# Altium schematic sheet SH1 - HSD.SchDoc
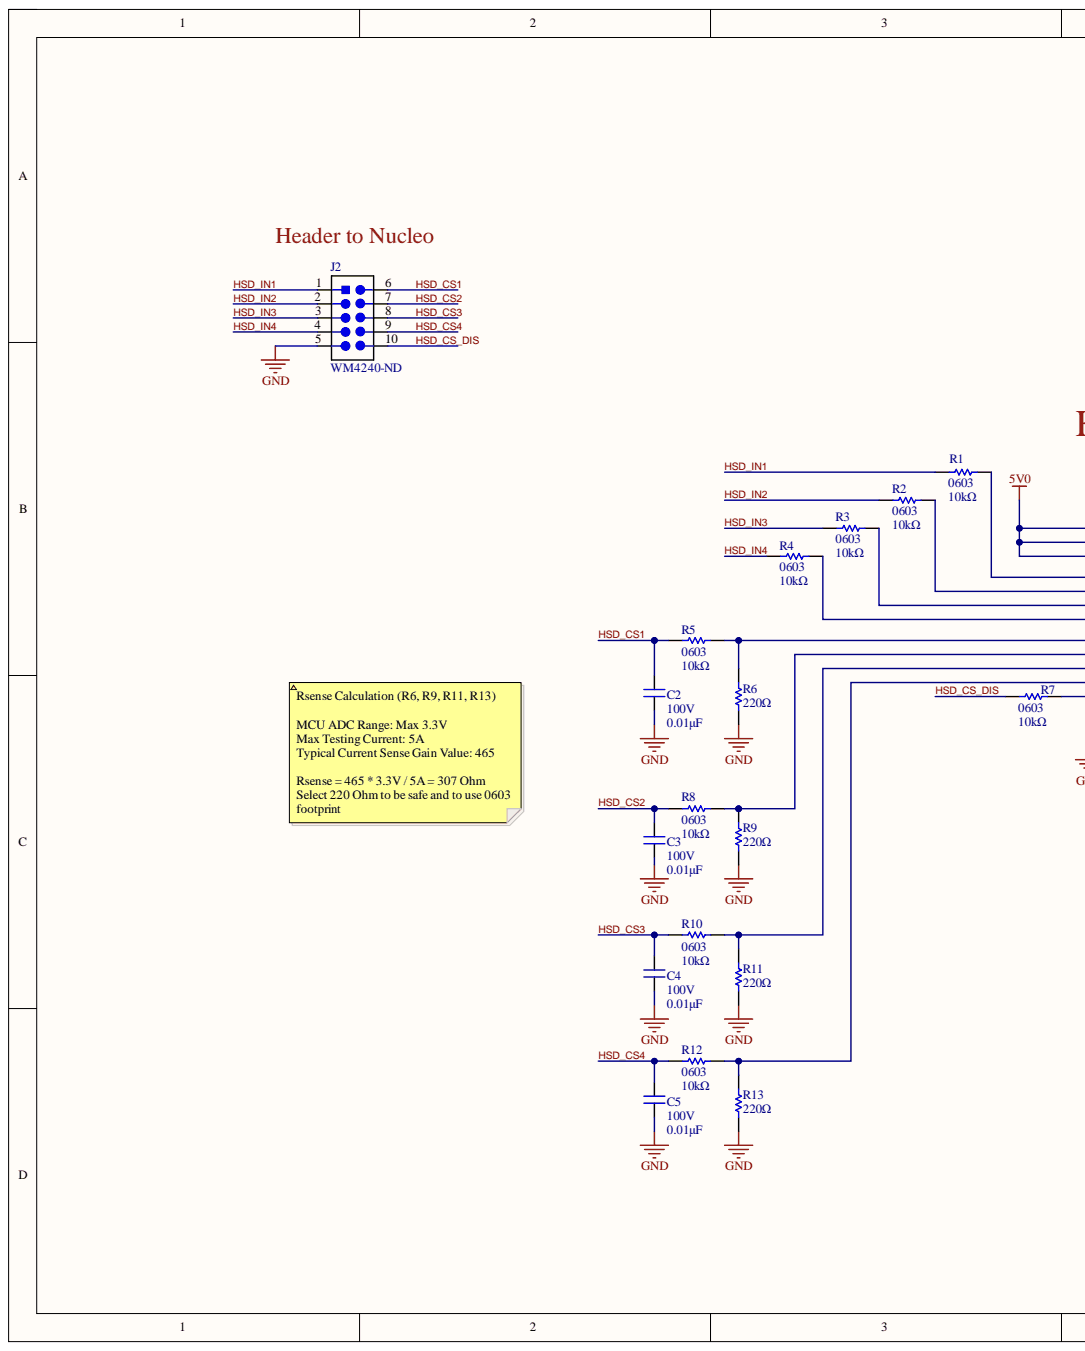
[diagram p0: SH1 - HSD.SchDoc, left]
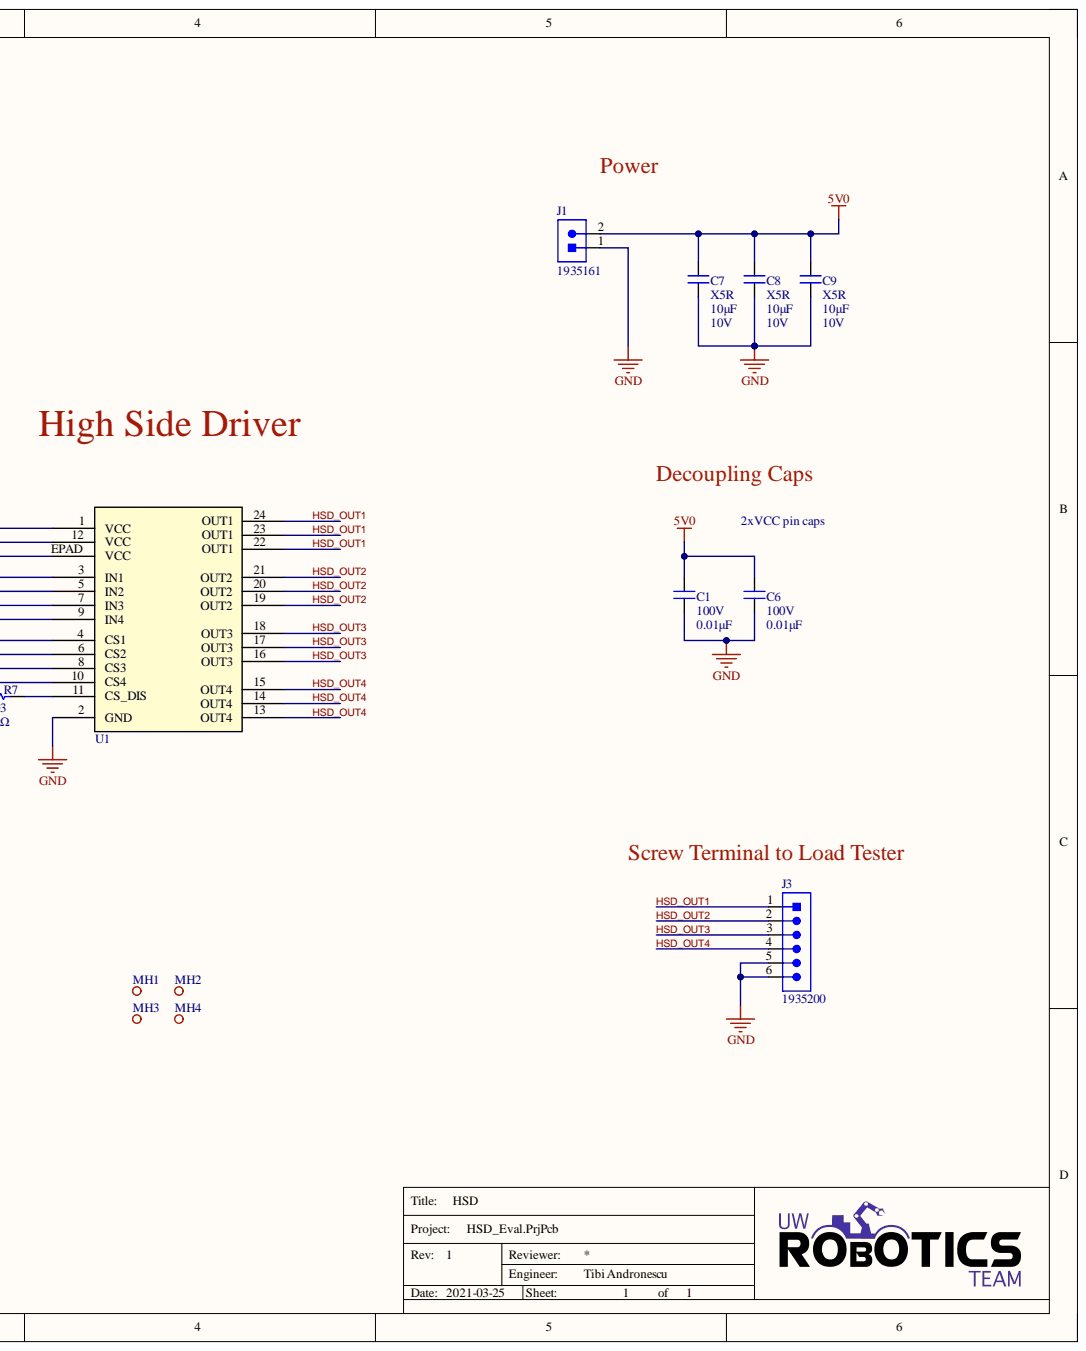
[diagram p1: SH1 - HSD.SchDoc, right]

## Parts
C1  value 0.01μF  Voltage Rating: 100V  [CAP0603]  (hidden comment: CAP_0.01uF_100V_0603)
C2  value 0.01μF  Voltage Rating: 100V  [CAP0603]  (hidden comment: CAP_0.01uF_100V_0603)
C3  value 0.01μF  Voltage Rating: 100V  [CAP0603]  (hidden comment: CAP_0.01uF_100V_0603)
C4  value 0.01μF  Voltage Rating: 100V  [CAP0603]  (hidden comment: CAP_0.01uF_100V_0603)
C5  value 0.01μF  Voltage Rating: 100V  [CAP0603]  (hidden comment: CAP_0.01uF_100V_0603)
C6  value 0.01μF  Voltage Rating: 100V  [CAP0603]  (hidden comment: CAP_0.01uF_100V_0603)
C7  value 10μF  Dielectric: X5R  Voltage Rating: 10V  [CAP0603]  (hidden comment: CAP_10uF_10V_0603)
C8  value 10μF  Dielectric: X5R  Voltage Rating: 10V  [CAP0603]  (hidden comment: CAP_10uF_10V_0603)
C9  value 10μF  Dielectric: X5R  Voltage Rating: 10V  [CAP0603]  (hidden comment: CAP_10uF_10V_0603)
J1  CON_2M_TERM  [1935161]  (value not drawn)
J2  Supplier Part Number 1: WM4240-ND  [HEADER 2X5]  (hidden comment: HEADER 5x2)
J3  CON_6POS_SCREW_TERM  [1935200]  (value not drawn)
MH1  MOUNTING_HOLE_M3  [MOUNTING_HOLE_3mm]  (value not drawn)  (no BOM)
MH2  MOUNTING_HOLE_M3  [MOUNTING_HOLE_3mm]  (value not drawn)  (no BOM)
MH3  MOUNTING_HOLE_M3  [MOUNTING_HOLE_3mm]  (value not drawn)  (no BOM)
MH4  MOUNTING_HOLE_M3  [MOUNTING_HOLE_3mm]  (value not drawn)  (no BOM)
R1  Package/Footprint: 0603  [RESC0603]  (hidden comment: RES_10kΩ_0603_5%)
R2  value 10kΩ  Package/Footprint: 0603  [RESC0603]  (hidden comment: RES_10kΩ_0603_5%)
R3  value 10kΩ  Package/Footprint: 0603  [RESC0603]  (hidden comment: RES_10kΩ_0603_5%)
R4  value 10kΩ  Package/Footprint: 0603  [RESC0603]  (hidden comment: RES_10kΩ_0603_5%)
R5  value 10kΩ  Package/Footprint: 0603  [RESC0603]  (hidden comment: RES_10kΩ_0603_5%)
R6  value 220Ω  [RESC0603]  (hidden comment: RES_220Ω_0603)
R7  value 10kΩ  Package/Footprint: 0603  [RESC0603]  (hidden comment: RES_10kΩ_0603_5%)
R8  value 10kΩ  Package/Footprint: 0603  [RESC0603]  (hidden comment: RES_10kΩ_0603_5%)
R9  value 220Ω  [RESC0603]  (hidden comment: RES_220Ω_0603)
R10  value 10kΩ  Package/Footprint: 0603  [RESC0603]  (hidden comment: RES_10kΩ_0603_5%)
R11  value 220Ω  [RESC0603]  (hidden comment: RES_220Ω_0603)
R12  value 10kΩ  Package/Footprint: 0603  [RESC0603]  (hidden comment: RES_10kΩ_0603_5%)
R13  value 220Ω  [RESC0603]  (hidden comment: RES_220Ω_0603)
U1  VNQ5E160AK-E  [PowerSSO-24_STM]  (value not drawn)

## Nets
5V0: C1.2 C6.2 C7.2 C8.2 C9.2 J1.2 U1.1(VCC) U1.12(VCC) U1.EPAD(VCC)
GND: C1.1 C2.1 C3.1 C4.1 C5.1 C6.1 C7.1 C8.1 C9.1 J1.1 J2.5 J3.5 J3.6 R6.1 R9.1 R11.1 R13.1 U1.2(GND)
HSD_CS1: C2.2 J2.6 R5.1
HSD_CS2: C3.2 J2.7 R8.1
HSD_CS3: C4.2 J2.8 R10.1
HSD_CS4: C5.2 J2.9 R12.1
HSD_CS_DIS: J2.10 R7.1
HSD_IN1: J2.1 R1.1
HSD_IN2: J2.2 R2.1
HSD_IN3: J2.3 R3.1
HSD_IN4: J2.4 R4.1
HSD_OUT1: J3.1 U1.22(OUT1) U1.23(OUT1) U1.24(OUT1)
HSD_OUT2: J3.2 U1.19(OUT2) U1.20(OUT2) U1.21(OUT2)
HSD_OUT3: J3.3 U1.16(OUT3) U1.17(OUT3) U1.18(OUT3)
HSD_OUT4: J3.4 U1.13(OUT4) U1.14(OUT4) U1.15(OUT4)
NetR1_2: R1.2 U1.3(IN1)
NetR2_2: R2.2 U1.5(IN2)
NetR3_2: R3.2 U1.7(IN3)
NetR4_2: R4.2 U1.9(IN4)
NetR5_2: R5.2 R6.2 U1.4(CS1)
NetR7_2: R7.2 U1.11(CS_DIS)
NetR8_2: R8.2 R9.2 U1.6(CS2)
NetR10_2: R10.2 R11.2 U1.8(CS3)
NetR12_2: R12.2 R13.2 U1.10(CS4)

## Library data of the parts
C1-C6 = CAP_0.01uF_100V_0603: CAP CER 10000PF 100V X7R 0603; Manufacturer 1: Murata Electronics; Manufacturer Part Number 1: GCM188R72A103KA37J; Component Kind: Standard; Dielectric: X7R; Supplier 1: Digi-Key; Supplier Part Number 1: 490-8028-1-ND; Library Name: UWRT_Schematic_Library_2020.SchLib
C7-C9 = CAP_10uF_10V_0603: CAP CER 10UF 10V X5R 0603; Manufacturer 1: Yageo; Manufacturer Part Number 1: CC0603MRX5R6BB106; Component Kind: Standard; Supplier 1: Digi-Key; Supplier Part Number 1: 311-1817-1-ND; Library Name: UWRT_Schematic_Library_2020.SchLib
J1 = CON_2M_TERM: TERM BLK 2POS SIDE ENTRY 5MM; Manufacturer 1: Phoenix Contact; Current: 18A; Max Current Rating: 16A; Package/Footprint: SMD; Voltage: 300V; Component Kind: Standard; Manufacturer Part Number 1: 1935161; Mounting Type: TH; Supplier 1: Digi-Key; Supplier Part Number 1: 277-1667-ND; Library Name: UWRT_Schematic_Library_2020.SchLib
J2 = HEADER 5x2: CONN HEADER VERT 10POS 2.54MM; Manufacturer 1: Molex; Manufacturer Part Number 1: 0901512210; Connector Type: Receptacle; Number of Contacts: 10; Pitch: 0.1inch; Category: Headers and Wire Housings; Color: Black; Component Kind: Standard; ComponentLink1Description: https://datasheet.ciiva.com/755/901512210-sd-755814.pdf?src-supplier=Digi-Key; ComponentLink1URL: https://datasheet.ciiva.com/755/901512210-sd-755814.pdf?src-supplier=Digi-Key; Contact Material: Bronze; Contact Plating: Gold; Contact Type: Female Socket; Depth: 5.08mm; Housing Color: Black; Housing Material: Polyester; Length: 12.7mm; Max Operating Temperature: 125°C; Min Operating Temperature: -55°C; Mount: Through Hole; Number of Positions: 10; Number of Rows: 2; Orientation: Straight; Packaging: Rail/Tube; RoHS Compliant: Yes; Row Spacing: 0.1inch; Series: C-Grid III™ 90151; Stock 1: 3613; Supplier 1: Digi-Key; Termination: Solder; Verified: No; Library Name: UWRT_Schematic_Library_2020.SchLib
J3 = CON_6POS_SCREW_TERM: TERM BLK 6POS SIDE ENTRY 5MM; Manufacturer 1: Phoenix Contact; Package/Footprint: TH; Screw Thread: M2.6; Wire Gauge: 12-26AWG; Component Kind: Standard; Manufacturer Part Number 1: 1935200; Mounting Type: TH; Pitch: 5mm; Supplier 1: Digi-Key; Supplier Part Number 1: 277-1581-ND; Library Name: UWRT_Schematic_Library_2020.SchLib
R1 R8 R10 R12 = RES_10kΩ_0603_5%: RES 10K OHM 5% 1/10W 0603; Manufacturer 1: Yageo; Manufacturer Part Number 1: RC0603JR-0710KL; Value: 10kΩ; Component Kind: Standard; Mounting Type: SMD; Supplier 1: Digi-Key; Supplier Part Number 1: 311-10KGRCT-ND; Library Name: UWRT_Schematic_Library_2020.SchLib
R2-R5 R7 = RES_10kΩ_0603_5%: RES 10K OHM 5% 1/10W 0603; Manufacturer 1: Yageo; Manufacturer Part Number 1: RC0603JR-0710KL; Component Kind: Standard; Mounting Type: SMD; Supplier 1: Digi-Key; Supplier Part Number 1: 311-10KGRCT-ND; Library Name: UWRT_Schematic_Library_2020.SchLib
R6 R9 R11 R13 = RES_220Ω_0603_5%: RES 220 OHM 5% 1/10W 0603; Manufacturer 1: Yageo; Manufacturer Part Number 1: AC0603JR-07220RL; Component Kind: Standard; Supplier 1: Digi-Key; Supplier Part Number 1: YAG3652CT-ND; Library Name: UWRT_Schematic_Library_2020.SchLib
U1 = VNQ5E160AK-E: Power Switch/Driver 1:1 N-Channel 7A PowerSSO-24; Manufacturer 1: STMicroelectronics; Load Voltage: 4.5V ~ 28V; Max Output Current: 10A; Number of Outputs: 4; On-State Resistance: 160mR; Output Configuration: High Side; Rds On (Typ): 160mOhm (Max); Category: Hot Swap Controllers; Component Kind: Standard; ComponentLink1Description: https://datasheet.ciiva.com/1956/cd00163285-1956669.pdf?src-supplier=Digi-Key; ComponentLink1URL: https://datasheet.ciiva.com/1956/cd00163285-1956669.pdf?src-supplier=Digi-Key; Lead Free: Lead Free; Manufacturer Part Number 1: VNQ5E160AK-E; Max Operating Temperature: 150°C; Min Operating Temperature: -40°C; Mounting Type: SM; Number of Pins: 24; Output Current per Channel: 7A; Series: VIPower™; Supplier 1: Digi-Key; Supplier Part Number 1: 497-19256-ND; Verified: No; Library Name: UWRT_Schematic_Library_2020.SchLib
MH1-MH4 = MOUNTING_HOLE_M3: Description: MOUNTING_HOLE_M3; Library Name: UWRT_Schematic_Library_2020.SchLib
